# Altium schematic sheet 3_A9912FH-PCB1-BRIDGE_DRIVER_V1.SchDoc(3_A9912FH-PCB1-BRIDGE_DRIVER_V1)
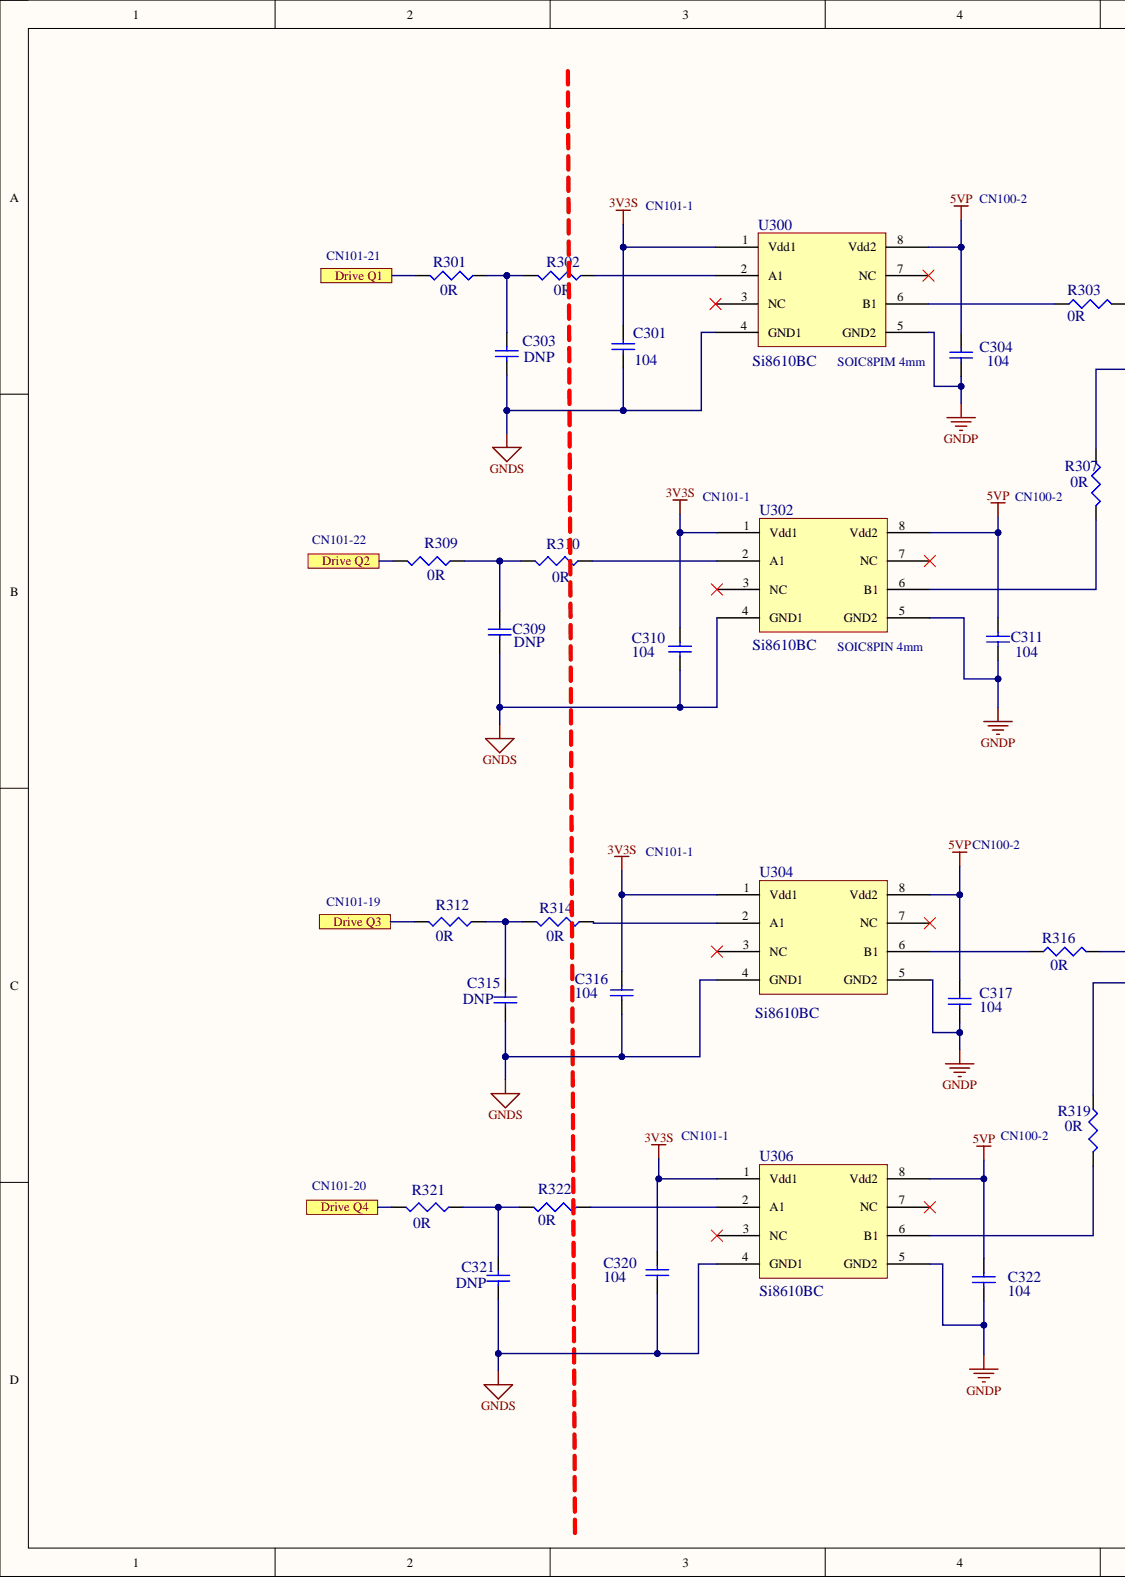
[diagram p0: 3_A9912FH-PCB1-BRIDGE_DRIVER_V1.SchDoc, left]
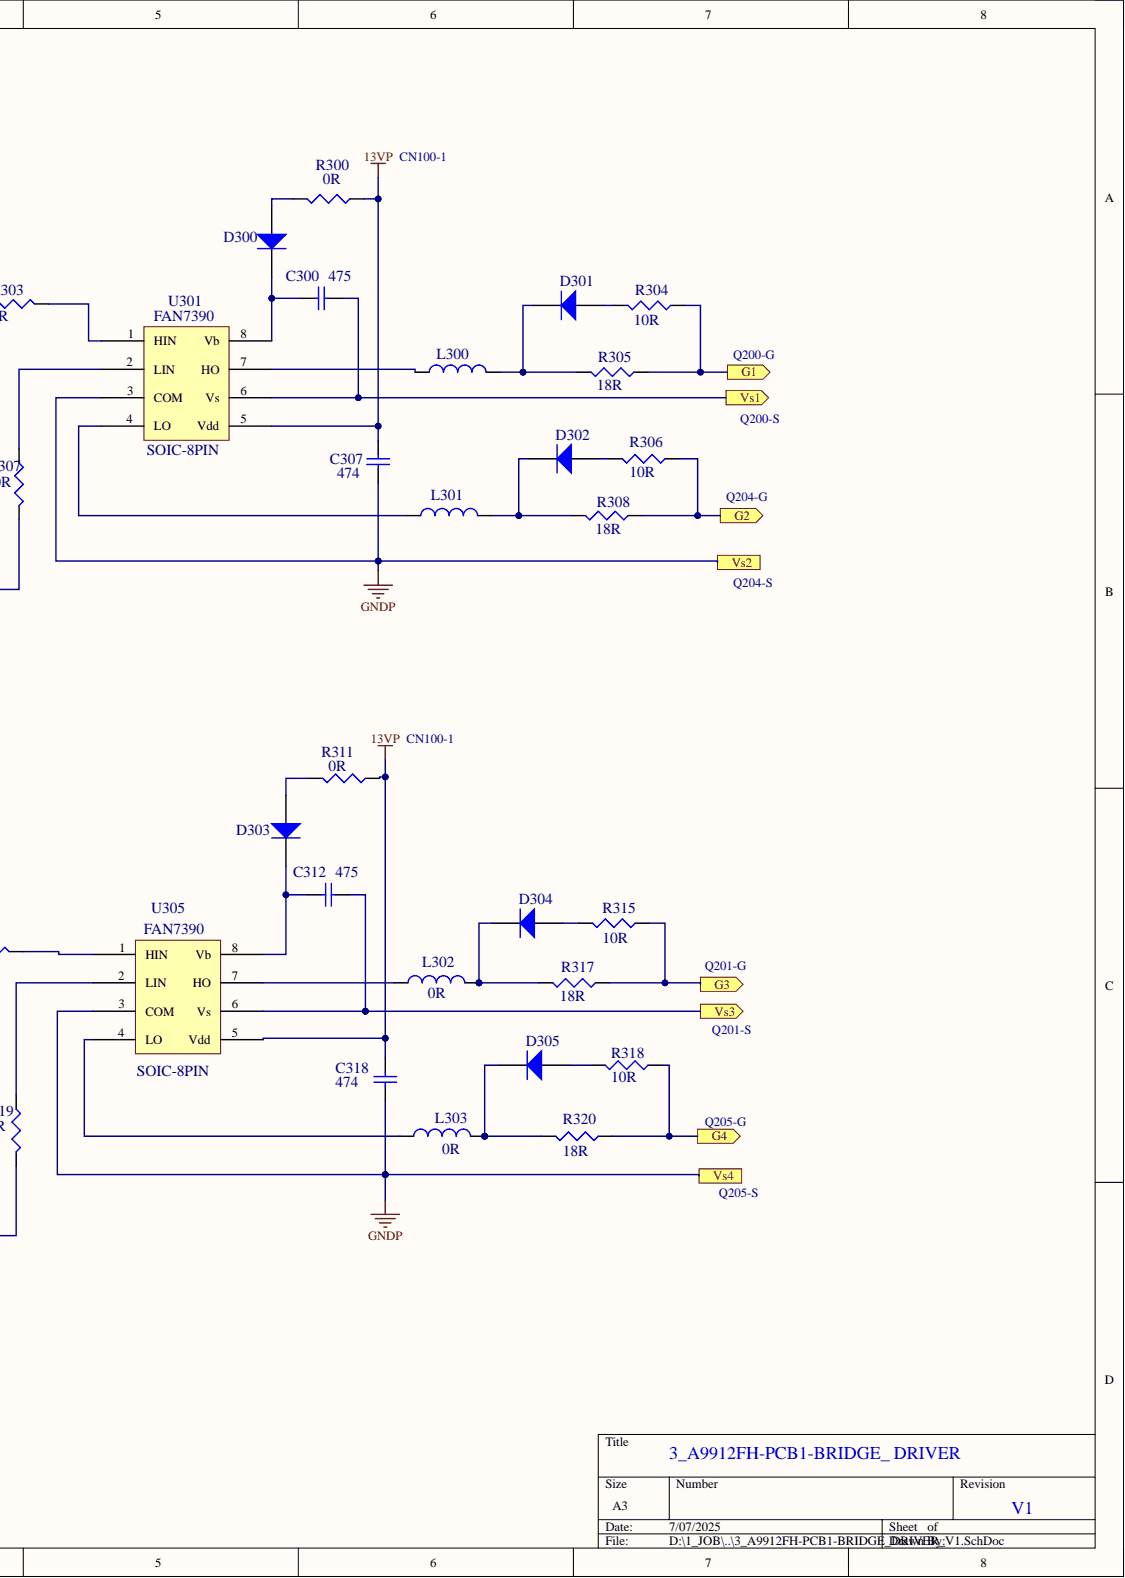
[diagram p1: 3_A9912FH-PCB1-BRIDGE_DRIVER_V1.SchDoc, right]

## Parts
C300  475  [CS-0805]
C301  104  [CS-0805]
C303  DNP  [CS-0805]
C304  104  [CS-0805]
C307  474  [CS-0805]
C309  DNP  [CS-0805]
C310  104  [CS-0805]
C311  104  [CS-0805]
C312  475  [CS-0805]
C315  DNP  [CS-0805]
C316  104  [CS-0805]
C317  104  [CS-0805]
C318  474  [CS-0805]
C320  104  [CS-0805]
C321  DNP  [CS-0805]
C322  104  [CS-0805]
D300  MUR160E3/5BT  [DO-214AA, SMB]  (value not drawn)
D301  MBR0540T1G  [SOD-123]  (value not drawn)
D302  MBR0540T1G  [SOD-123]  (value not drawn)
D303  MUR160E3/5BT  [DO-214AA, SMB]  (value not drawn)
D304  MBR0540T1G  [SOD-123]  (value not drawn)
D305  MBR0540T1G  [SOD-123]  (value not drawn)
L300  Inductor  [LS-0805]  (value not drawn)
L301  Inductor  [RS-0805]  (value not drawn)
L302  value 0R  [LS-0805]  (hidden comment: Inductor)
L303  value 0R  [LS-0805]  (hidden comment: Inductor)
R300  0R  [RS-0805]
R301  0R  [RS-0805]
R302  0R  [RS-0805]
R303  0R  [RS-0805]
R304  10R  [RS-0805]
R305  18R  [RS-0805]
R306  10R  [RS-0805]
R307  0R  [RS-0805]
R308  18R  [RS-0805]
R309  0R  [RS-0805]
R310  0R  [RS-0805]
R311  0R  [RS-0805]
R312  0R  [RS-0805]
R314  0R  [RS-0805]
R315  10R  [RS-0805]
R316  0R  [RS-0805]
R317  18R  [RS-0805]
R318  10R  [RS-0805]
R319  0R  [RS-0805]
R320  18R  [RS-0805]
R321  0R  [RS-0805]
R322  0R  [RS-0805]
U300  Si8610BC  [IC-SSOP-P1_27-8]
U301  FAN7390  PackageReference: SOIC-8PIN  [8-SOIC (0.154", 3.90mm Width)]
U302  Si8610BC  [IC-SSOP-P1_27-8]
U304  Si8610BC  [IC-SSOP-P1_27-8]
U305  FAN7390  PackageReference: SOIC-8PIN  [8-SOIC (0.154", 3.90mm Width)]
U306  Si8610BC  [IC-SSOP-P1_27-8]

## Ports
Drive Q1, Drive Q2, Drive Q3, Drive Q4, G1, G2, G3, G4, Vs1, Vs2, Vs3, Vs4

## Nets
3V3S: C301.2 C310.2 C316.2 C320.2 U300.1(Vdd1) U302.1(Vdd1) U304.1(Vdd1) U306.1(Vdd1)
5VP: C304.2 C311.2 C317.2 C322.2 U300.8(Vdd2) U302.8(Vdd2) U304.8(Vdd2) U306.8(Vdd2)
13VP: C307.2 C318.2 R300.2 R311.2 U301.5(Vdd) U305.5(Vdd)
GNDS: C301.1 C303.1 C309.1 C310.1 C315.1 C316.1 C320.1 C321.1 U300.4(GND1) U302.4(GND1) U304.4(GND1) U306.4(GND1)
Drive Q1: R301.1 ; port Drive Q1
Drive Q2: R309.1 ; port Drive Q2
Drive Q3: R312.1 ; port Drive Q3
Drive Q4: R321.1 ; port Drive Q4
G1: R304.2 R305.2 ; port G1
G2: R306.2 R308.2 ; port G2
G3: R315.2 R317.2 ; port G3
G4: R318.2 R320.2 ; port G4
GNDP: C304.1 C307.1 C311.1 C317.1 C318.1 C322.1 U300.5(GND2) U301.3(COM) U302.5(GND2) U304.5(GND2) U305.3(COM) U306.5(GND2) ; port Vs2, port Vs4
Vs1: C300.2 U301.6(Vs) ; port Vs1
Vs3: C312.2 U305.6(Vs) ; port Vs3
NetC300_1: C300.1 D300.K U301.8(Vb)
NetC303_2: C303.2 R301.2 R302.1
NetC309_2: C309.2 R309.2 R310.1
NetC312_1: C312.1 D303.K U305.8(Vb)
NetC315_2: C315.2 R312.2 R314.1
NetC321_2: C321.2 R321.2 R322.1
NetD300_A: D300.A R300.1
NetD301_A: D301.A R304.1
NetD301_K: D301.K L300.2 R305.1
NetD302_A: D302.A R306.1
NetD302_K: D302.K L301.2 R308.1
NetD303_A: D303.A R311.1
NetD304_A: D304.A R315.1
NetD304_K: D304.K L302.2 R317.1
NetD305_A: D305.A R318.1
NetD305_K: D305.K L303.2 R320.1
NetL300_1: L300.1 U301.7(HO)
NetL301_1: L301.1 U301.4(LO)
NetL302_1: L302.1 U305.7(HO)
NetL303_1: L303.1 U305.4(LO)
NetR302_2: R302.2 U300.2(A1)
NetR303_1: R303.1 U301.1(HIN)
NetR303_2: R303.2 U300.6(B1)
NetR307_1: R307.1 U302.6(B1)
NetR307_2: R307.2 U301.2(LIN)
NetR310_2: R310.2 U302.2(A1)
NetR314_2: R314.2 U304.2(A1)
NetR316_1: R316.1 U305.1(HIN)
NetR316_2: R316.2 U304.6(B1)
NetR319_1: R319.1 U306.6(B1)
NetR319_2: R319.2 U305.2(LIN)
NetR322_2: R322.2 U306.2(A1)

Not connected: U300 3(NC) 7(NC); U302 3(NC) 7(NC); U304 3(NC) 7(NC); U306 3(NC) 7(NC)

## Library data of the parts
C300 C301 C303 C304 C307 C309-C312 C315-C318 C320-C322 = Cap Semi: Capacitor (Semiconductor SIM Model); Component Kind: Standard; LatestRevisionDate: 29-May-2009; LatestRevisionNote: IPC-7351 Footprint Added.; Library Name: Miscellaneous Devices.IntLib; PackageDescription: Chip Capacitor; Published: 8-Jun-2000; Signal Integrity: Cap Semi; Simulation: CAP; Publisher: Altium Limited
  C300 C312: PackageReference: C1210; Value: 475
  C301 C304 C310 C311 C316 C317 C320 C322: PackageReference: CAP0805; Value: 104
  C303 C309 C315 C321: PackageReference: CAP0805; Value: DNP
  C307 C318: PackageReference: CAP0805; Value: 474
D300 D303 = Diode 1N4001: 1 Amp General Purpose Rectifier; Disti PartNumber: MURS160T3GOSDKR-ND; Description/Value: DIODE ULTRA FAST 1A 600V SMB; Component Kind: Standard; Library Name: Miscellaneous Devices.IntLib; PackageDescription: DO-214-AA/SMB; 2 C-Bend Leads; Body 5.3 x 3.6 mm, inc. leads (LxW); PackageReference: DO214AB; PartNumber: MUR160E3/5BT; Signal Integrity: 1N4001; Simulation: 1N4001
D301 D302 D304 D305 = Diode 1N4001: 1 Amp General Purpose Rectifier; Disti PartNumber: MBR0540T1GOSCT-ND; Description/Value: DIODE SCHOTTKY 40V 0.5A SOD123; Component Kind: Standard; Library Name: Miscellaneous Devices.IntLib; PackageDescription: Name	Type	Description	Vault	Item Revision; PackageReference: SOD_123; PartNumber: MBR0540T1G; Signal Integrity: 1N4001; Simulation: 1N4001
L300 L301 = Inductor: Code_IEC: 1005; Value: 0R; Code_JEITA: 0402; Component Kind: Standard; Description: Inductor; LatestRevisionDate: 29-May-2009; LatestRevisionNote: IPC-7351 Footprint Added.; Library Name: Miscellaneous Devices.IntLib; PackageDescription: Chip Inductor; PackageReference: IN0805_N; Published: 8-Jun-2000; Signal Integrity: Inductor; Simulation: INDUCTOR; Publisher: Altium Limited
L302 L303 = Inductor: Code_IEC: 1005; Code_JEITA: 0402; Component Kind: Standard; Description: Inductor; LatestRevisionDate: 29-May-2009; LatestRevisionNote: IPC-7351 Footprint Added.; Library Name: Miscellaneous Devices.IntLib; PackageDescription: Chip Inductor; PackageReference: IN0805_N; Published: 8-Jun-2000; Signal Integrity: Inductor; Simulation: INDUCTOR; Publisher: Altium Limited
R300-R312 R314-R322 = Res3: Resistor; Component Kind: Standard; LatestRevisionDate: 29-May-2009; LatestRevisionNote: IPC-7351 Footprint Added.; Library Name: Miscellaneous Devices.IntLib; PackageDescription: Chip Resistor; PackageReference: RES0805; Published: 8-Jun-2000; Signal Integrity: Res; Simulation: RESISTOR; Publisher: Altium Limited
  R300-R303 R307 R309-R312 R314 R316 R319 R321 R322: Value: 0R
  R304 R306 R315 R318: Value: 10R
  R305 R308 R317 R320: Value: 18R
U300 U302 U304 U306 = Si8610BC SOIC8PIN 4mm: Component Kind: Standard; Library Name: SNM_Comp.SchLib
U301 U305 = FAN7390: Disti PartNumber: FAN7390MXTR-ND; PartNumber: FAN7390MX; Description/Value: IC DRIVER GATE HI/LO SIDE 8-SOP; Component Kind: Standard; Library Name: SNM_Comp.SchLib
